# Altium schematic sheet LMS_RF.SchDoc(LMS_RF)
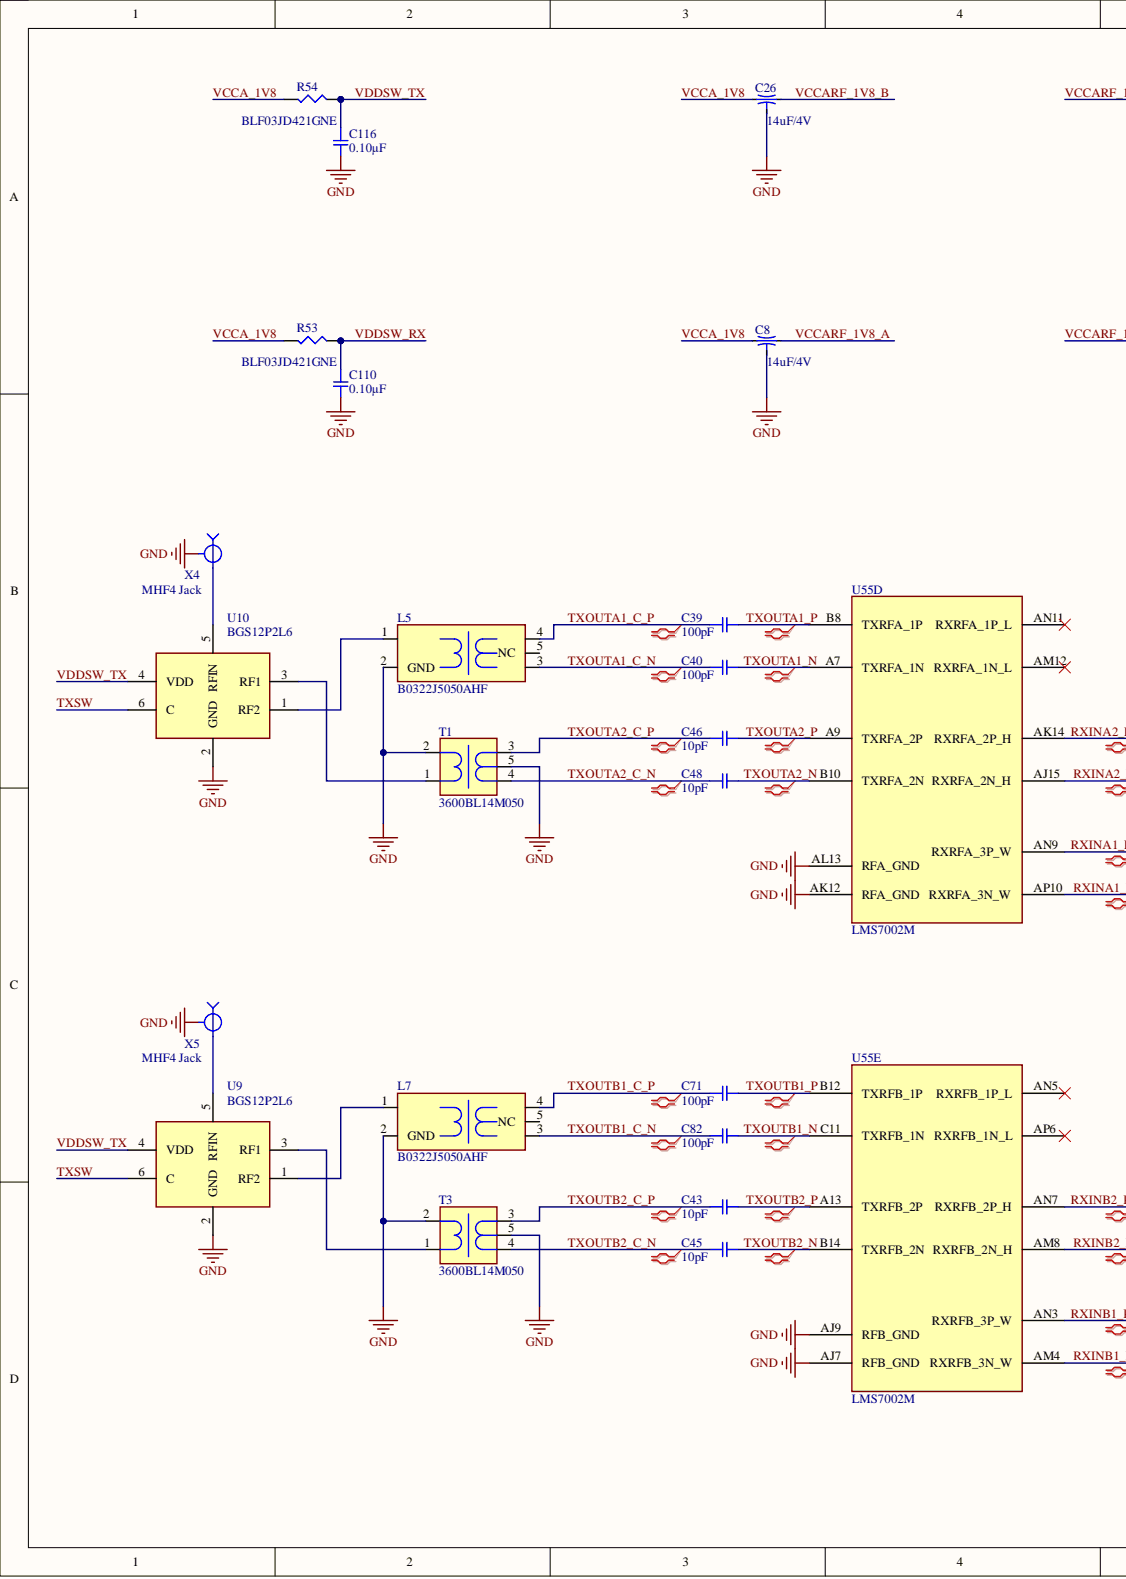
[diagram p0: LMS_RF.SchDoc, left]
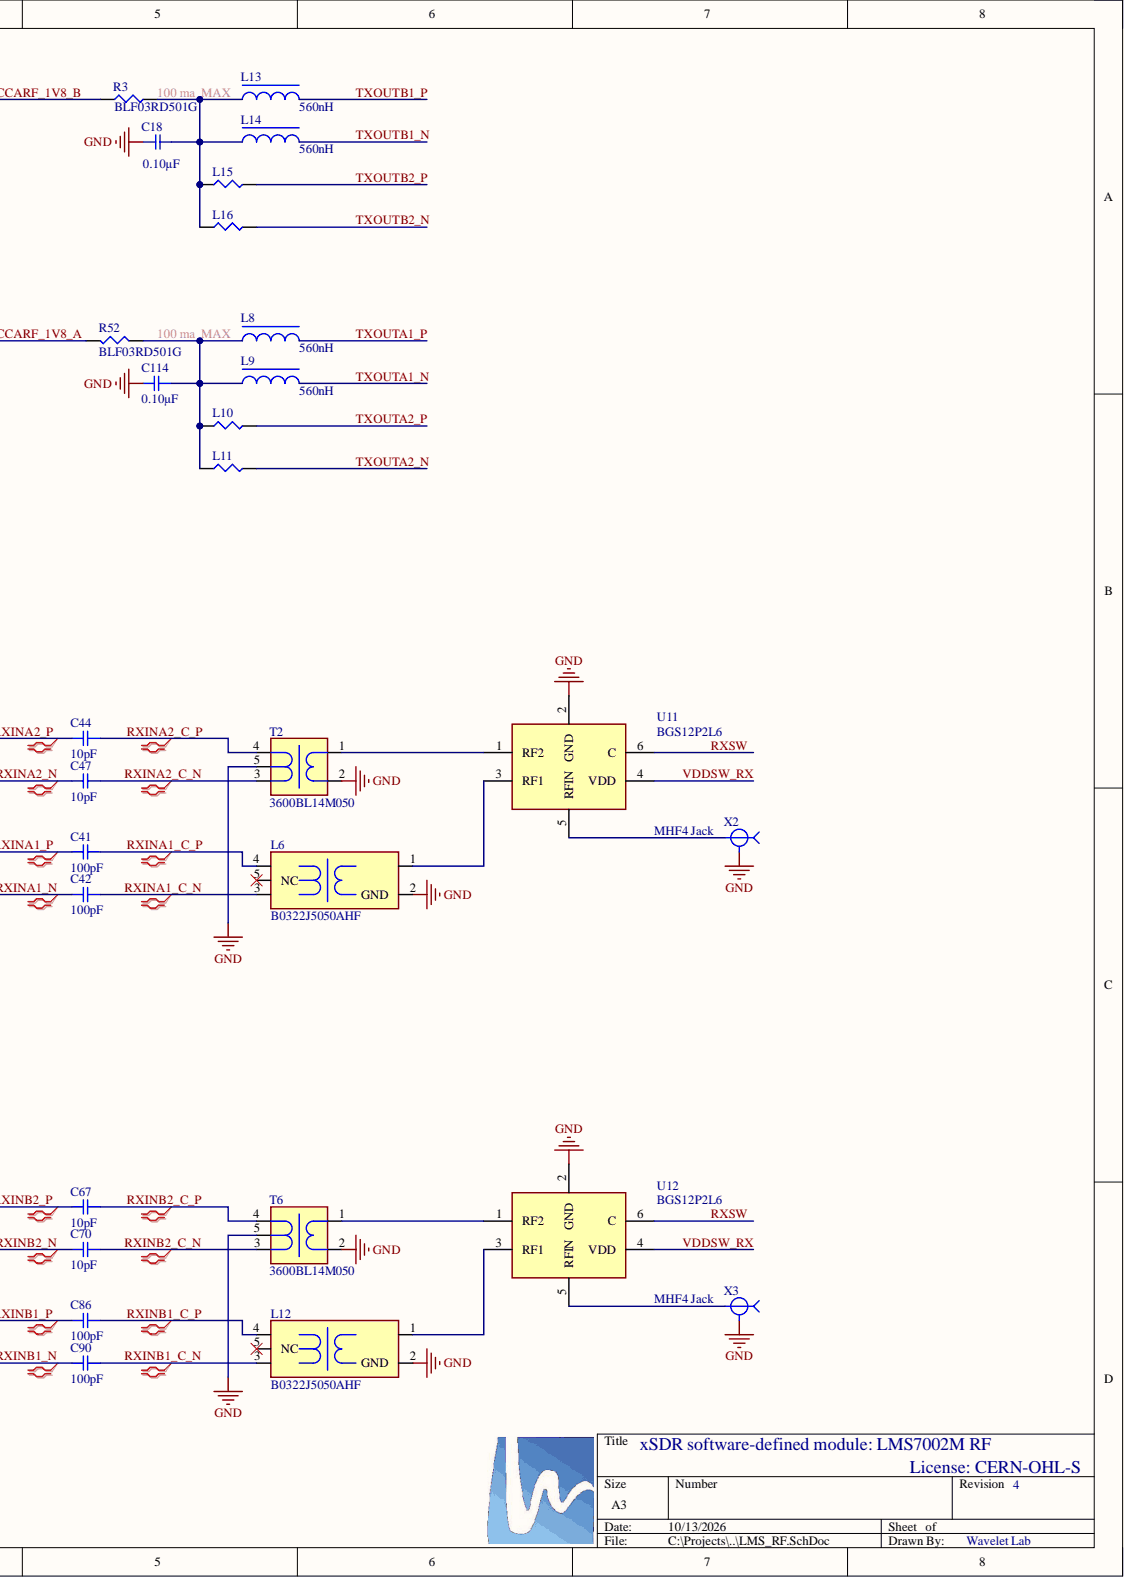
[diagram p1: LMS_RF.SchDoc, right]

## Parts
C8  14uF/4V  [NFM15]
C18  value 0.10µF  [SMD-0201C]
C26  14uF/4V  [NFM15]
C39  value 100pF  [SMD-0201C]
C40  value 100pF  [SMD-0201C]
C41  value 100pF  [SMD-0201C]
C42  value 100pF  [SMD-0201C]
C43  value 10pF  [SMD-0201C]
C44  value 10pF  [SMD-0201C]
C45  value 10pF  [SMD-0201C]
C46  value 10pF  [SMD-0201C]
C47  value 10pF  [SMD-0201C]
C48  value 10pF  [SMD-0201C]
C67  value 10pF  [SMD-0201C]
C70  value 10pF  [SMD-0201C]
C71  value 100pF  [SMD-0201C]
C82  value 100pF  [SMD-0201C]
C86  value 100pF  [SMD-0201C]
C90  value 100pF  [SMD-0201C]
C110  value 0.10µF  [SMD-0201C]
C114  value 0.10µF  [SMD-0201C]
C116  value 0.10µF  [SMD-0201C]
L5  B0322J5050AHF  [BALUN 2.04x1.39x0.71]
L6  B0322J5050AHF  [BALUN 2.04x1.39x0.71]
L7  B0322J5050AHF  [BALUN 2.04x1.39x0.71]
L8  560nH  [026011F]
L9  560nH  [026011F]
L10  (no value)  [SMD-0201-RES]
L11  (no value)  [SMD-0201-RES]
L12  B0322J5050AHF  [BALUN 2.04x1.39x0.71]
L13  560nH  [026011F]
L14  560nH  [026011F]
L15  (no value)  [SMD-0201-RES]
L16  (no value)  [SMD-0201-RES]
R3  value BLF03RD501G  [SMD-0201-RES]
R52  value BLF03RD501G  [SMD-0201-RES]
R53  value BLF03JD421GNE  [SMD-0201-RES]
R54  value BLF03JD421GNE  [SMD-0201-RES]
T1  value 3600BL14M050  [Balun 1.6x0.8x0.6mm]
T2  value 3600BL14M050  [Balun 1.6x0.8x0.6mm]
T3  value 3600BL14M050  [Balun 1.6x0.8x0.6mm]
T6  value 3600BL14M050  [Balun 1.6x0.8x0.6mm]
U9  BGS12P2L6  [TSLP-6-4]
U10  BGS12P2L6  [TSLP-6-4]
U11  BGS12P2L6  [TSLP-6-4]
U12  BGS12P2L6  [TSLP-6-4]
U55  LMS7002M  [AQFN260]  (parts D,E)
X2  MHF4 Jack  value MHF4  [MHF4]
X3  MHF4 Jack  value MHF4  [MHF4]
X4  MHF4 Jack  value MHF4  [MHF4]
X5  MHF4 Jack  value MHF4  [MHF4]

## Nets
GND: C8.2 C18.1 C26.2 C110.2 C114.2 C116.2 L5.2(GND) L6.2(GND) L7.2(GND) L12.2(GND) T1.2 T1.5 T2.2 T2.5 T3.2 T3.5 T6.2 T6.5 U9.2(GND) U10.2(GND) U11.2(GND) U12.2(GND) U55.AJ7(RFB_GND) U55.AJ9(RFB_GND) U55.AK12(RFA_GND) U55.AL13(RFA_GND) X2.2 X3.2 X4.2 X5.2
RXINA1_C_N: C42.1 L6.3
RXINA1_C_P: C41.1 L6.4
RXINA1_N: C42.2 U55.AP10(RXRFA_3N_W)
RXINA1_P: C41.2 U55.AN9(RXRFA_3P_W)
RXINA2_C_N: C47.1 T2.3
RXINA2_C_P: C44.1 T2.4
RXINA2_N: C47.2 U55.AJ15(RXRFA_2N_H)
RXINA2_P: C44.2 U55.AK14(RXRFA_2P_H)
RXINB1_C_N: C90.1 L12.3
RXINB1_C_P: C86.1 L12.4
RXINB1_N: C90.2 U55.AM4(RXRFB_3N_W)
RXINB1_P: C86.2 U55.AN3(RXRFB_3P_W)
RXINB2_C_N: C70.1 T6.3
RXINB2_C_P: C67.1 T6.4
RXINB2_N: C70.2 U55.AM8(RXRFB_2N_H)
RXINB2_P: C67.2 U55.AN7(RXRFB_2P_H)
RXSW: U11.6(C) U12.6(C)
TXOUTA1_C_N: C40.1 L5.3
TXOUTA1_C_P: C39.1 L5.4
TXOUTA1_N: C40.2 L9.2 U55.A7(TXRFA_1N)
TXOUTA1_P: C39.2 L8.2 U55.B8(TXRFA_1P)
TXOUTA2_C_N: C48.1 T1.4
TXOUTA2_C_P: C46.1 T1.3
TXOUTA2_N: C48.2 L11.2 U55.B10(TXRFA_2N)
TXOUTA2_P: C46.2 L10.2 U55.A9(TXRFA_2P)
TXOUTB1_C_N: C82.1 L7.3
TXOUTB1_C_P: C71.1 L7.4
TXOUTB1_N: C82.2 L14.2 U55.C11(TXRFB_1N)
TXOUTB1_P: C71.2 L13.2 U55.B12(TXRFB_1P)
TXOUTB2_C_N: C45.1 T3.4
TXOUTB2_C_P: C43.1 T3.3
TXOUTB2_N: C45.2 L16.2 U55.B14(TXRFB_2N)
TXOUTB2_P: C43.2 L15.2 U55.A13(TXRFB_2P)
TXSW: U9.6(C) U10.6(C)
VCCA_1V8: C8.1 C26.1 R53.2 R54.2
VCCARF_1V8_A: C8.3 R52.2
VCCARF_1V8_B: C26.3 R3.1
VDDSW_RX: C110.1 R53.1 U11.4(VDD) U12.4(VDD)
VDDSW_TX: C116.1 R54.1 U9.4(VDD) U10.4(VDD)
NetC18_2: C18.2 L13.1 L14.1 L15.1 L16.1 R3.2
NetC114_1: C114.1 L8.1 L9.1 L10.1 L11.1 R52.1
NetL5_1: L5.1 U10.1(RF2)
NetL6_1: L6.1 U11.3(RF1)
NetL7_1: L7.1 U9.1(RF2)
NetL12_1: L12.1 U12.3(RF1)
NetT1_1: T1.1 U10.3(RF1)
NetT2_1: T2.1 U11.1(RF2)
NetT3_1: T3.1 U9.3(RF1)
NetT6_1: T6.1 U12.1(RF2)
NetU9_5: U9.5(RFIN) X5.1
NetU10_5: U10.5(RFIN) X4.1
NetU11_5: U11.5(RFIN) X2.1
NetU12_5: U12.5(RFIN) X3.1

Not connected: L5 5(NC); L6 5(NC); L7 5(NC); L12 5(NC); U55 AM12(RXRFA_1N_L) AN5(RXRFB_1P_L) AN11(RXRFA_1P_L) AP6(RXRFB_1N_L)

## Library data of the parts
C8 C26 = NFM15: Filter EMI 0402 Feedthrough; Manufacturer: MuRata; Name: NFM15PC146R0G3D; Tolerance: 20%; U/P/TKE: 4V/2A/30mO/-55+85℃; Component Kind: Standard; Link: https://octopart.com/nfm15pc146r0g3d-murata-94414995; PDF: https://datasheet.octopart.com/NFM15PC146R0G3D-Murata-datasheet-138760002.pdf; Value: 14uF/4V; Library Name: USDR.IntLib
C18 C110 C114 C116 = GRM033R60J104ME19D: CAP CER 0.1UF 6.3V X5R 0201; Manufacturer: Murata Electronics North America; @ qty: 0; Minimum Quantity: 1; Tolerance: ±20%; Unit Price (USD): 0.1; Applications: General Purpose; Component Kind: Standard; Datasheets: http://www.murata.com/~/media/webrenewal/support/library/catalog/products/capacitor/mlcc/c02e.pdf; Digi-Key Part Number: 490-5404-1-ND; Factory Stock: 0; Failure Rate: Surface Mount, MLCC; Features: -; Height - Seated (Max): 0.013" (0.33mm); Image: //media.digikey.com/Renders/Murata%20Renders/ZRB-SERIES-0402.jpg; Lead Spacing: -; Mounting Type: 0201 (0603 Metric); Operating Temperature: -55°C ~ 85°C; Package / Case: 0.024" L x 0.012" W (0.60mm x 0.30mm); Packaging: Cut Tape (CT); Part Number: GRM033R60J104ME19D; Part Status: Active; Quantity Available: 381529; Ratings: -; Series: GRM; Size / Dimension: -; Temperature Coefficient: X5R; Thickness (Max): -; Voltage: 6.3V; Library Name: USDR.IntLib
C39-C48 C67 C70 C71 C82 C86 C90 = GRM0335C1H101JA01D: CAP CER 100PF 50V NP0 0201; Manufacturer: Murata Electronics North America; @ qty: 0; Minimum Quantity: 1; Temperature Coefficient: C0G, NP0; Tolerance: ±5%; Unit Price (USD): 0.1; Applications: General Purpose; Component Kind: Standard; Datasheets: http://www.murata.com/~/media/webrenewal/support/library/catalog/products/capacitor/mlcc/c02e.pdf; Digi-Key Part Number: 490-6113-1-ND; Factory Stock: 0; Failure Rate: Surface Mount, MLCC; Features: -; Height - Seated (Max): -; Image: //media.digikey.com/Renders/Murata%20Renders/ZRB-SERIES-0402.jpg; Mounting Type: 0201 (0603 Metric); Operating Temperature: -55°C ~ 125°C; Package / Case: 0.024" L x 0.012" W (0.60mm x 0.30mm); Packaging: Cut Tape (CT); Part Number: GRM0335C1H101JA01D; Part Status: Active; Quantity Available: 19110; Ratings: -; Series: GRM; Size / Dimension: -; Thickness (Max): -; Voltage: 50V; Library Name: USDR.IntLib
L5-L7 L12 = B0322J5050AHF: Balun bal-unbal; Manufacturer: Anaren; Name: Balun 50/50 400-2300 Mhz; Component Kind: Standard; Link: https://octopart.com/search?q=B0322J5050AHF&currency=USD&specs=0; Value: B0322J5050AHF; Library Name: USDR.IntLib
L8 L9 L13 L14 = 026011F: RF Choke; Manufacturer: Coilcraft; Name: 026011F-561XJR_; Component Kind: Standard; Link: https://www.coilcraft.com/getmedia/1ea2f021-e135-4ba6-a1fb-80ee6d0887d3/026011f.pdf; Value: 560nH; Library Name: USDR.IntLib
L10 L11 L15 L16 = ERJ-1GEJ106C: RES SMD 10M OHM 5% 1/20W 0201; Manufacturer: Panasonic Electronic Components; @ qty: 1500; Factory Stock: 0; Minimum Quantity: 1; Power (Watts): 0.05W, 1/20W; Temperature Coefficient: -400/ +150ppm/°C; Unit Price (USD): 0.00787; Value: BLF03RD501G; Component Kind: Standard; Composition: Thick Film; Datasheets: //media.digikey.com/pdf/Data%20Sheets/Panasonic%20Resistors%20Thermistors%20PDFs/ERJ%205%25.pdf; Digi-Key Part Number: P10MAGCT-ND; Failure Rate: -; Features: -; Height - Seated (Max): 0.010" (0.26mm); Image: //media.digikey.com/Renders/Panasonic%20Renders/ERJ-0201%20Pkg.jpg; Number of Terminations: 2; Operating Temperature: -55°C ~ 125°C; Package / Case: 0201 (0603 Metric); Packaging: Cut Tape (CT); Part Number: ERJ-1GEJ106C; Part Status: Active; Quantity Available: 91403; Series: ERJ; Size / Dimension: 0.024" L x 0.012" W (0.60mm x 0.30mm); Supplier Device Package: 0201; Tolerance: ±5%; Library Name: USDR.IntLib
R3 R52 = ERJ-1GE0R00C: RES SMD 0.0OHM JUMPER 1/20W 0201; Manufacturer: Panasonic Electronic Components; @ qty: 1500; Factory Stock: 0; Minimum Quantity: 1; Power (Watts): 0.05W, 1/20W; Unit Price (USD): 0.00960; Component Kind: Standard; Composition: Thick Film; Datasheets: //media.digikey.com/pdf/Data%20Sheets/Panasonic%20Resistors%20Thermistors%20PDFs/ERJ_G_Rev06.pdf; Digi-Key Part Number: P0.0AGCT-ND; Failure Rate: -; Features: -; Height - Seated (Max): 0.010" (0.26mm); Image: //media.digikey.com/Renders/Panasonic%20Renders/ERJ-0201%20Pkg.jpg; Number of Terminations: 2; Operating Temperature: -55°C ~ 125°C; Package / Case: 0201 (0603 Metric); Packaging: Cut Tape (CT); Part Number: ERJ-1GE0R00C; Part Status: Active; Quantity Available: 2883022; Series: ERJ; Size / Dimension: 0.024" L x 0.012" W (0.60mm x 0.30mm); Supplier Device Package: 0201; Temperature Coefficient: -; Tolerance: Jumper; Library Name: USDR.IntLib
R53 R54 = ERJ-1GE0R00C: RES SMD 0.0OHM JUMPER 1/20W 0201; Manufacturer: Panasonic Electronic Components; @ qty: 1500; Factory Stock: 0; Minimum Quantity: 1; Power (Watts): 0.05W, 1/20W; Unit Price (USD): 0.00960; Value: BLF03JD421GNE; Component Kind: Standard; Composition: Thick Film; Datasheets: //media.digikey.com/pdf/Data%20Sheets/Panasonic%20Resistors%20Thermistors%20PDFs/ERJ_G_Rev06.pdf; Digi-Key Part Number: P0.0AGCT-ND; Failure Rate: -; Features: -; Height - Seated (Max): 0.010" (0.26mm); Image: //media.digikey.com/Renders/Panasonic%20Renders/ERJ-0201%20Pkg.jpg; Number of Terminations: 2; Operating Temperature: -55°C ~ 125°C; Package / Case: 0201 (0603 Metric); Packaging: Cut Tape (CT); Part Number: ERJ-1GE0R00C; Part Status: Active; Quantity Available: 2883022; Series: ERJ; Size / Dimension: 0.024" L x 0.012" W (0.60mm x 0.30mm); Supplier Device Package: 0201; Temperature Coefficient: -; Tolerance: Jumper; Library Name: USDR.IntLib
T1-T3 T6 = Balun 3-4GHz: Balun bal-unbal; Manufacturer: Johanson Technology, Inc.; Name: Balun 50/50 3-4 GHz; Component Kind: Standard; Link: https://octopart.com/3600bl14m050t-johanson-11760610; Library Name: USDR.IntLib
U9-U12 = BGS12P2L6: IC RF SP4T Switch; Manufacturer: Infineon; Misc: SPDT 0.05-6 GHz RF Switch; U/P/TKE: Vcc 1.65-3.4V/Vcont 1.35-Vcc; Component Kind: Standard; Link: https://www.infineon.com/dgdl/Infineon-BGS12P2L6-DataSheet-v02_00-EN.pdf?fileId=5546d4626cb27db2016d4487d53603ce; Name: BGS12P2L6; Library Name: USDR.IntLib
U55 = LMS7002M: FPRF MIMO Transceiver IC; Manufacturer: Lime Microsystems; Misc: Multi-band Multi-standard  Transceiver with Digital Interface; Component Kind: Standard; Name: LMS7002M; Library Name: USDR.IntLib
X2-X5 = MHF4 Jack: Connector (I-PEX MHF4); Alternate Manufacturer: Linx Technologies; Manufacturer: Taoglas; Alternate Name: CONMHF4-SMD-G; Name: RECE.20449.001E.01; Alternate Link: https://linxtechnologies.com/wp/wp-content/uploads/conmhf4-smd-g-t-ds.pdf; Component Kind: Standard; Link: https://www.taoglas.com/datasheets/RECE.20449.001E.01.pdf; Library Name: USDR.IntLib
  X2 X3: Value: MHF4
